# Altium schematic sheet Carte_Alim_2019_TOP.SchDoc(Carte_Alim_2019_TOP)
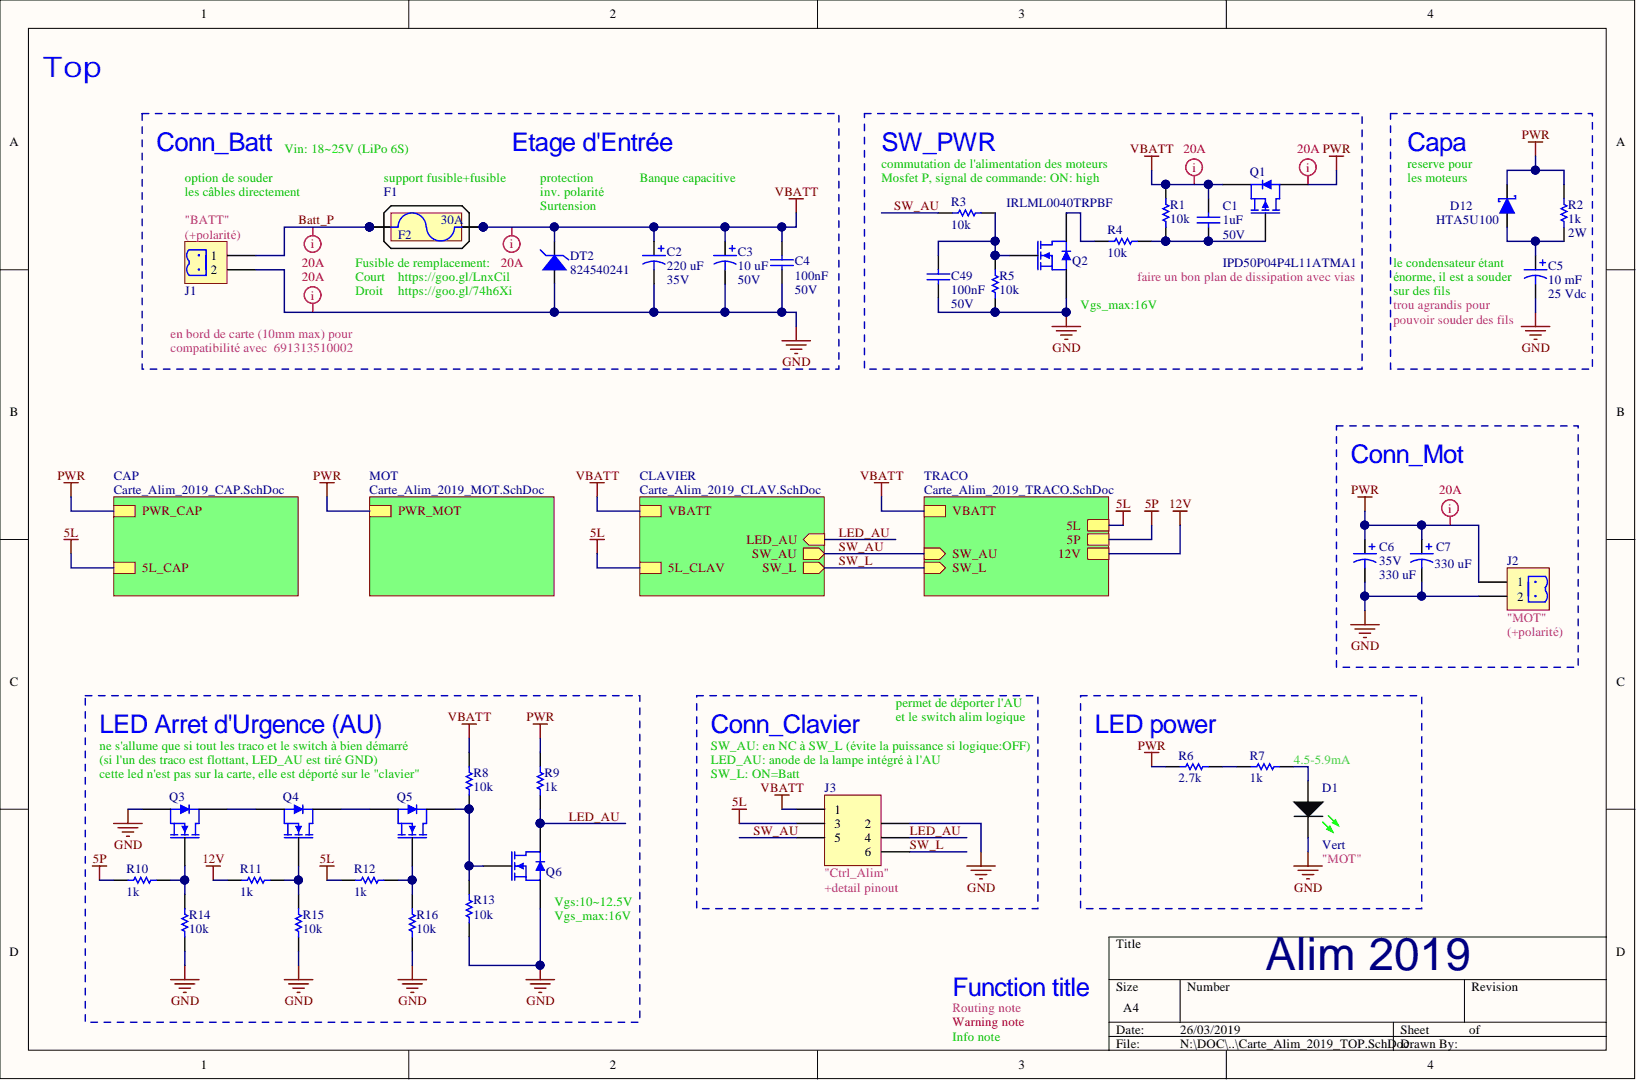
[diagram p0: Carte_Alim_2019_TOP.SchDoc]

## Parts
C1  1uF  RatedVoltage: 50V  [WCAP-CSGP_0805, 2x1.25x1.25]
C2  220 uF  RatedVoltage: 35V  [WCAP-ATG8_8x11.5x3.5x0.5]
C3  10 uF  RatedVoltage: 50V  [WCAP-ATG8_5x11x2x0.5]
C4  100nF  RatedVoltage: 50V  [WCAP-CSGP_0603, 1.6x0.8x0.8]
C5  10 mF  Rated Voltage: 25 Vdc  [WCAP-ATG8_22x41x10x0.8]
C6  330 uF  RatedVoltage: 35V  [WCAP-ATG8_10x12.5x5x0.6]
C7  330 uF  [WCAP-ATG8_10x12.5x5x0.6]
C49  100nF  RatedVoltage: 50V  [WCAP-CSGP_0603, 1.6x0.8x0.8]
D1  Vert  [SMCW_0603]
D12  HTA5U100  [SMA Diode (DO-214AC)]
DT2  824540241  [DO-214AB_Unidirectional]
F1  Courant nominal: 30A  [3557-2]  (hidden comment: 3557-2)
F2  Courant nominal: 30A  (hidden comment: 0891030.NXS)  (mechanical)
J1  value 2  [691311500102]  (hidden comment: 691311500102)
J2  value 2  [691311500102]  (hidden comment: 691311500102)
J3  value 6  [690367280676]  (hidden comment: 690367280676)
Q1  IPD50P04P4L11ATMA1  [DPAK]
Q2  IRLML0040TRPBF  [SOT-23]
Q3  IRLML0040TRPBF  [SOT-23]
Q4  IRLML0040TRPBF  [SOT-23]
Q5  IRLML0040TRPBF  [SOT-23]
Q6  IRLML0040TRPBF  [SOT-23]
R1  value 10k  [RESC1608X06N]  (hidden comment: RC0603FR-0710KL)
R2  1k  Power: 2W  [RESADV480W80L1200D390]
R3  value 10k  [RESC1608X06N]  (hidden comment: RC0603FR-0710KL)
R4  value 10k  [RESC1608X06N]  (hidden comment: RC0603FR-0710KL)
R5  value 10k  [RESC1608X06N]  (hidden comment: RC0603FR-0710KL)
R6  value 2.7k  [RESC2012X06M]  (hidden comment: RC0805FR-072K7L)
R7  value 1k  [RESC1608X06N]  (hidden comment: RC0603FR-071KL)
R8  value 10k  [RESC1608X06N]  (hidden comment: RC0603FR-0710KL)
R9  value 1k  [RESC1608X06N]  (hidden comment: RC0603FR-071KL)
R10  value 1k  [RESC1608X06N]  (hidden comment: RC0603FR-071KL)
R11  value 1k  [RESC1608X06N]  (hidden comment: RC0603FR-071KL)
R12  value 1k  [RESC1608X06N]  (hidden comment: RC0603FR-071KL)
R13  value 10k  [RESC1608X06N]  (hidden comment: RC0603FR-0710KL)
R14  value 10k  [RESC1608X06N]  (hidden comment: RC0603FR-0710KL)
R15  value 10k  [RESC1608X06N]  (hidden comment: RC0603FR-0710KL)
R16  value 10k  [RESC1608X06N]  (hidden comment: RC0603FR-0710KL)

## Nets
5L: J3.3 R12.2
5P: R10.2
12V: R11.2
GND: C2.2 C3.2 C4.1 C5.2 C6.2 C7.2 C49.1 D1.1 DT2.1 J1.2 J2.2 J3.2 Q2.2 Q3.2 Q6.2 R5.2 R13.1 R14.1 R15.1 R16.1
PWR: C6.1 C7.1 D12.2 J2.1 Q1.4 R2.1 R6.1 R9.2
VBATT: C1.2 C2.1 C3.1 C4.2 DT2.2 F1.2(30A) F1.4 J3.1 Q1.2 R1.2 R8.2
Batt_P: F1.1 F1.3 J1.1
LED_AU: J3.4 Q6.3 R9.1
SW_AU: J3.5 R3.1
SW_L: J3.6
NetC1_1: C1.1 Q1.1 R1.1 R4.1
NetC5_1: C5.1 D12.1 R2.2
NetC49_2: C49.2 Q2.1 R3.2 R5.1
NetD1_2: D1.2 R7.1
NetQ2_3: Q2.3 R4.2
NetQ3_1: Q3.1 R10.1 R14.2
NetQ3_3: Q3.3 Q4.2
NetQ4_1: Q4.1 R11.1 R15.2
NetQ4_3: Q4.3 Q5.2
NetQ5_1: Q5.1 R12.1 R16.2
NetQ5_3: Q5.3 Q6.1 R8.1 R13.2
NetR6_2: R6.2 R7.2

## Library data of the parts
C1 = WCAP-CSGP, 885012207103: CAP CER 1uF 50V X7R 0805; Manufacturer: Wurth Elektronik; Value: 1uF; Size: 0805; PublishedDate: Aug 2016; Manufacturer Part Number: 885012207103; ComponentLink2URL: http://katalog.we-online.com/pbs/datasheet/885012207103.pdf; ComponentLink2Description: Datasheet; ComponentLink1URL: http://www.we-online.de; ComponentLink1Description: Manufacturer; Component Kind: Standard; CeramicType: X7R; Library Name: Condensateur.IntLib
C2 = WCAP-ATG8, 860010574011: CAP Alu 220 UF 20% 35 V; Manufacturer: Wurth Elektronik; Value: 220 uF; Size: 8mmx11.5mm; PublishedDate: July 2016; Manufacturer Part Number: 860010574011; ComponentLink2URL: http://katalog.we-online.de/pbs/datasheet/860010574011.pdf; ComponentLink2Description: Datasheet; ComponentLink1URL: http://www.we-online.de; ComponentLink1Description: Manufacturer; Component Kind: Standard; Library Name: Condensateur.IntLib
C3 = WCAP-ATG8, 860010672009: CAP Alu 10 UF 20% 50 V; Manufacturer: Wurth Elektronik; Value: 10 uF; Size: 5mmx11mm; PublishedDate: July 2016; Manufacturer Part Number: 860010672009; ComponentLink2URL: http://katalog.we-online.de/pbs/datasheet/860010672009.pdf; ComponentLink2Description: Datasheet; ComponentLink1URL: http://www.we-online.de; ComponentLink1Description: Manufacturer; Component Kind: Standard; Library Name: Condensateur.IntLib
C4 C49 = WCAP-CSGP, 885012206095: CAP CER 100nF 50V X7R 0603; Manufacturer: Wurth Elektronik; Value: 100nF; Size: 0603; PublishedDate: Aug 2016; Manufacturer Part Number: 885012206095; ComponentLink2URL: http://katalog.we-online.com/pbs/datasheet/885012206095.pdf; ComponentLink2Description: Datasheet; ComponentLink1URL: http://www.we-online.de; ComponentLink1Description: Manufacturer; Component Kind: Standard; CeramicType: X7R; Library Name: Condensateur.IntLib
C5 = WCAP-ATG8, 860010483029: CAP Alu 10'000 UF 25 V; Manufacturer: Wurth Elektronik; Value: 10 mF; Size: 22x41mm; Published Date: Sep. 2017; Manufacturer Part Number: 860010483029; ComponentLink2URL: http://katalog.we-online.de/pbs/datasheet/860010483029.pdf; ComponentLink2Description: Datasheet; ComponentLink1URL: http://www.we-online.de; ComponentLink1Description: Manufacturer; Component Kind: Standard; Library Name: Condensateur.IntLib
C6 = WCAP-ATG8, 860010575012: CAP Alu 330 UF 20% 35 V; Manufacturer: Wurth Elektronik; Value: 330 uF; Size: 10mmx12.5mm; PublishedDate: July 2016; Manufacturer Part Number: 860010575012; ComponentLink2URL: http://katalog.we-online.de/pbs/datasheet/860010575012.pdf; ComponentLink2Description: Datasheet; ComponentLink1URL: http://www.we-online.de; ComponentLink1Description: Manufacturer; Component Kind: Standard; Library Name: Condensateur.IntLib
C7 = WCAP-ATG8, 860010575012: CAP Alu 330 UF 20% 35 V; Manufacturer: Wurth Elektronik; RatedVoltage: 35V; Value: 330 uF; Size: 10mmx12.5mm; PublishedDate: July 2016; Manufacturer Part Number: 860010575012; ComponentLink2URL: http://katalog.we-online.de/pbs/datasheet/860010575012.pdf; ComponentLink2Description: Datasheet; ComponentLink1URL: http://www.we-online.de; ComponentLink1Description: Manufacturer; Component Kind: Standard; Library Name: Condensateur.IntLib
D1 = WL-SMCW 0603  150060GS75000: SMD mono-color Chip LED, WL-SMCW, Green; Manufacturer 1: Würth Elektronik eiSos GmbH; Value: Vert; Supplier Part Number 1: 2322070; Supplier 1: Farnell; Manufacturer Part Number: 150060GS75000; Created Date: June 2013; ComponentLink2URL: http://katalog.we-online.com/led/datasheet/150060GS75000.pdf; ComponentLink2Description: Datasheet; ComponentLink1URL: http://www.we-online.de; ComponentLink1Description: Manufacturer; Component Kind: Standard; Color: Green; Library Name: Optoélectronique.IntLib; Created by: Christin Otto
D12 = HTA5U100: DIODE SCHOTTKY 100V 5A SMA (DO-214AC); Manufacturer: MULTICOMP; ContinuousForwardCurrent: 5A (Pk 50A); Type: Schottky; Supplier Part Number 1: 2750942; Supplier 1: Farnell; ReverseVoltage: 100V; Manufacturer Part Number: HTA5U100; Library Name: Diode.IntLib; ComponentLink2URL: http://www.farnell.com/datasheets/2282884.pdf; ComponentLink2Description: Datasheet; ComponentLink1Description: Manufacturer; Component Kind: Standard
DT2 = 824540241: WE-TVSP Power TVS Diode, Unidirectional, 1500 W, 24 VDC; Manufacturer: Wurth Electronics Inc.; Supplier Part Number 1: 2536699; Supplier 1: Farnell; Manufacturer Part Number: 824540241; Library Name: Diode.IntLib; ComponentLink1URL: http://katalog.we-online.de/pbs/datasheet/824540241.pdf; ComponentLink1Description: Datasheet; Component Kind: Standard
F1 = 3557-2: FUSE Holder 500V 30A PCB; Manufacturer: Keystone Electronics; Supplier Part Number 1: 2292904; Supplier 1: Farnell; Manufacturer Part Number: 3557-2; Library Name: Fuse.IntLib; ComponentLink1URL: http://www.keyelco.com/product-pdf.cfm?p=296; ComponentLink1Description: Datasheet; Component Kind: Standard
F2 = 0891030.NXS: FUSE AUTO 30A 58VDC BLADE MINI; Manufacturer: Littelfuse Inc.; Supplier Part Number 1: F4993-ND; Supplier 1: Digi-Key; Manufacturer Part Number: 0891030.NXS; Library Name: Fuse.IntLib; ComponentLink1URL: http://www.littelfuse.com/~/media/automotive/datasheets/fuses/passenger-car-and-commercial-vehicle/blade-fuses/littelfuse_lowprofilemini_datasheet.pdf; ComponentLink1Description: Datasheet
J1 J2 = 691311500102: WR-TBL 2p Series 311 - 5.08 mm Closed Vertical PCB Header; Manufacturer: Wurth Electronics Inc.; Supplier Part Number 1: 1641978; Supplier 1: Farnell; Manufacturer Part Number: 691311500102; ComponentLink1URL: https://katalog.we-online.de/em/datasheet/6913115001xx.pdf; ComponentLink1Description: Datasheet; Component Kind: Standard; Library Name: Connecteurs.IntLib
J3 = 690367280676: WR-MM 6p Female SMT Connector with Polarization; Manufacturer: Wurth Electronics Inc.; Supplier Part Number 1: 1641849; Supplier 1: Farnell; Manufacturer Part Number: 690367280676; ComponentLink1URL: http://katalog.we-online.de/em/datasheet/69036728xx76.pdf; ComponentLink1Description: Datasheet; Component Kind: Standard; Library Name: Connecteurs.IntLib
Q1 = IPD50P04P4-L11: MOSFET P 40V 50A DPAK; Manufacturer: INFINEON; Supplier Part Number 1: 2443434; Supplier 1: Farnell; Manufacturer Part Number: IPD50P04P4L11ATMA1; Library Name: Transistors.IntLib; ComponentLink1URL: http://www.farnell.com/datasheets/1942428.pdf; ComponentLink1Description: Datasheet; Component Kind: Standard
Q2-Q6 = IRLML0040: MOSFET N 40V 3.6A SOT23; Manufacturer: INTERNATIONAL RECTIFIER; Supplier Part Number 1: 1831088; Supplier 1: Farnell; Manufacturer Part Number: IRLML0040TRPBF; Library Name: Transistors.IntLib; ComponentLink1URL: http://www.irf.com/product-info/datasheets/data/irlml0040pbf.pdf; ComponentLink1Description: http://www.irf.com/product-info/datasheets/data/irlml0040pbf.pdf; Component Kind: Standard
R1 R3-R5 R8 R13-R16 = RC0603FR-0710KL: RES SMD 10K OHM 1% 1/10W 0603; Manufacturer: Yageo; Vmax: 50V; Power: 100mW; Type: Thick Film; Supplier Part Number 1: 9238603; Supplier 1: Farnell; Precision: ±1%; Manufacturer Part Number: RC0603FR-0710KL; ComponentLink2URL: http://www.farnell.com/datasheets/2167052.pdf; ComponentLink2Description: Datasheet; ComponentLink1URL: http://www.yageo.com/NewPortal/_en/index.jsp; ComponentLink1Description: Manufacturer; Component Kind: Standard; Library Name: Resistance.IntLib
R2 = Generic_TH_Vert_Res: resistance traversante verticale générique; Manufacturer Part Number: MCKNP02WJ0102A19; Manufacturer: MULTICOMP; Precision: ±5%; Value: 1k; Type: Through Hole; Supplier Part Number 1: 1903754; Supplier 1: Farnell; Library Name: Resistance.IntLib; Component Kind: Standard
R6 = RC0805FR-072K7L: RES SMD 2K7 OHM 1% 1/8W 0805; Manufacturer: Yageo; Vmax: 50V; Power: 125mW; Type: Thick Film; Supplier Part Number 1: 9237666; Supplier 1: Farnell; Precision: ±1%; Manufacturer Part Number: RC0805FR-072K7L; ComponentLink2URL: http://www.farnell.com/datasheets/444164.pdf; ComponentLink2Description: Datasheet; ComponentLink1URL: http://www.yageo.com/NewPortal/_en/index.jsp; ComponentLink1Description: Manufacturer; Component Kind: Standard; Library Name: Resistance.IntLib
R7 R9-R12 = RC0603FR-071KL: RES SMD 1K OHM 1% 1/10W 0603; Manufacturer: Yageo; Vmax: 50V; Power: 100mW; Type: Thick Film; Supplier Part Number 1: 9238484; Supplier 1: Farnell; Precision: ±1%; Manufacturer Part Number: RC0603FR-071KL; ComponentLink2URL: http://www.farnell.com/datasheets/2167052.pdf; ComponentLink2Description: Datasheet; ComponentLink1URL: http://www.yageo.com/NewPortal/_en/index.jsp; ComponentLink1Description: Manufacturer; Component Kind: Standard; Library Name: Resistance.IntLib
